# Altium schematic sheet LED_garland.SchDoc
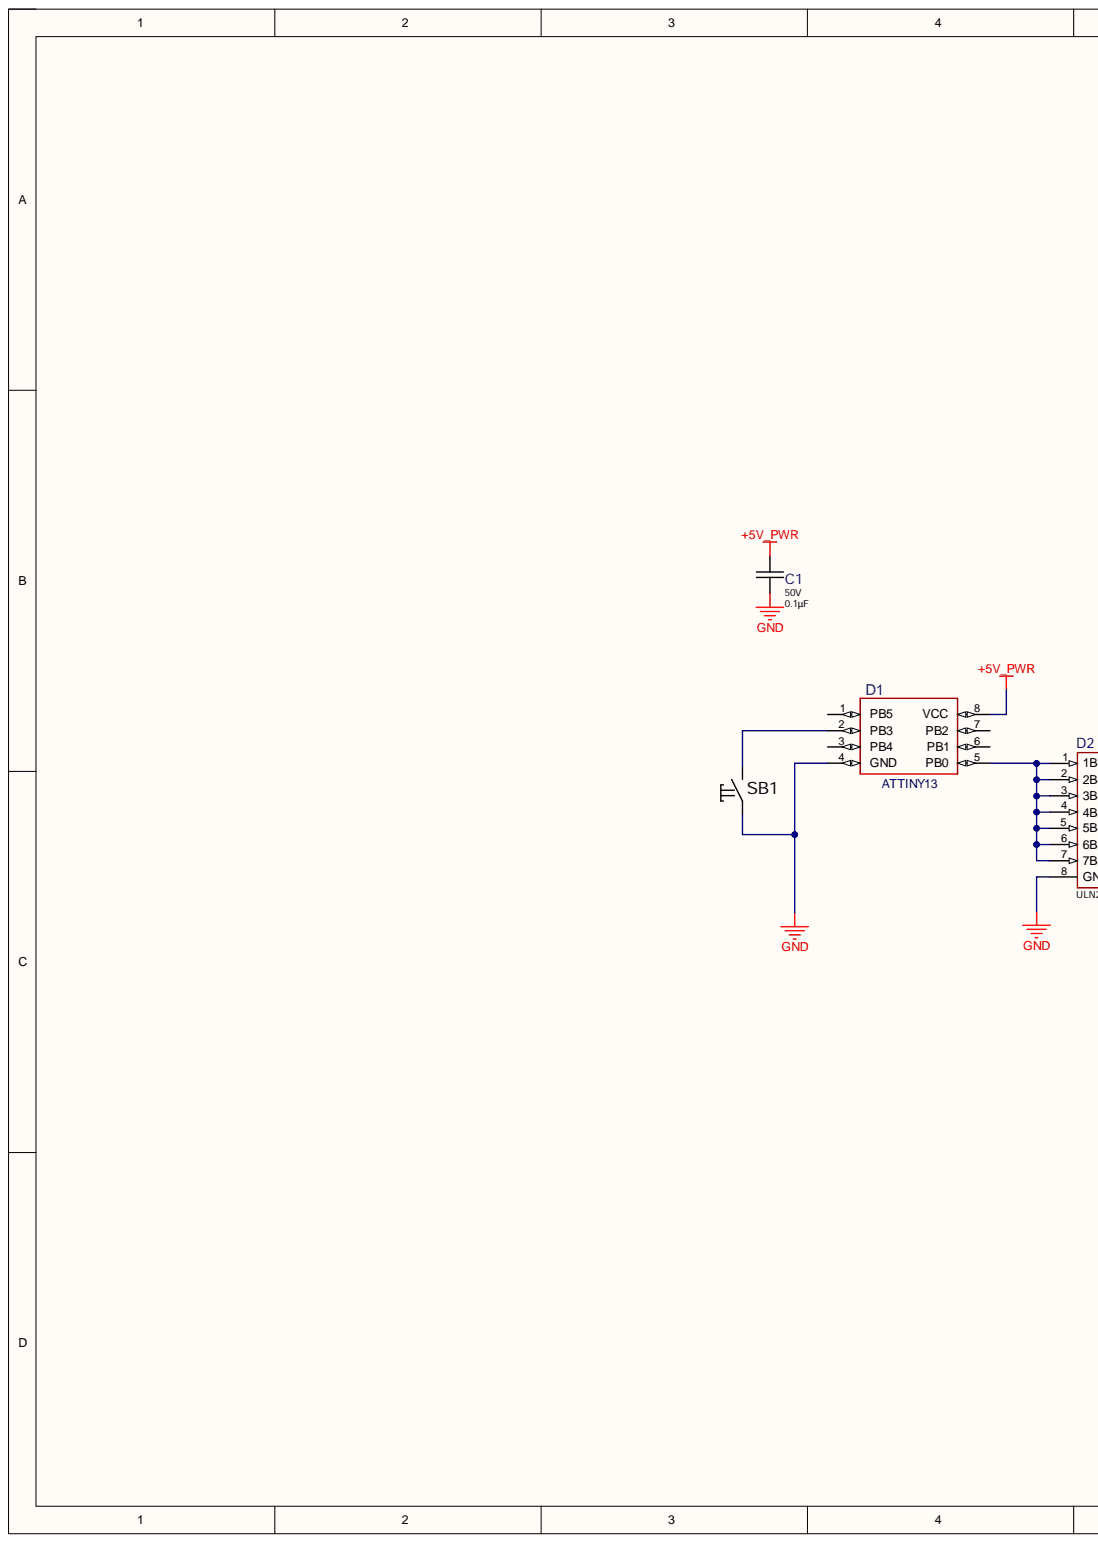
[diagram p0: LED_garland.SchDoc, left]
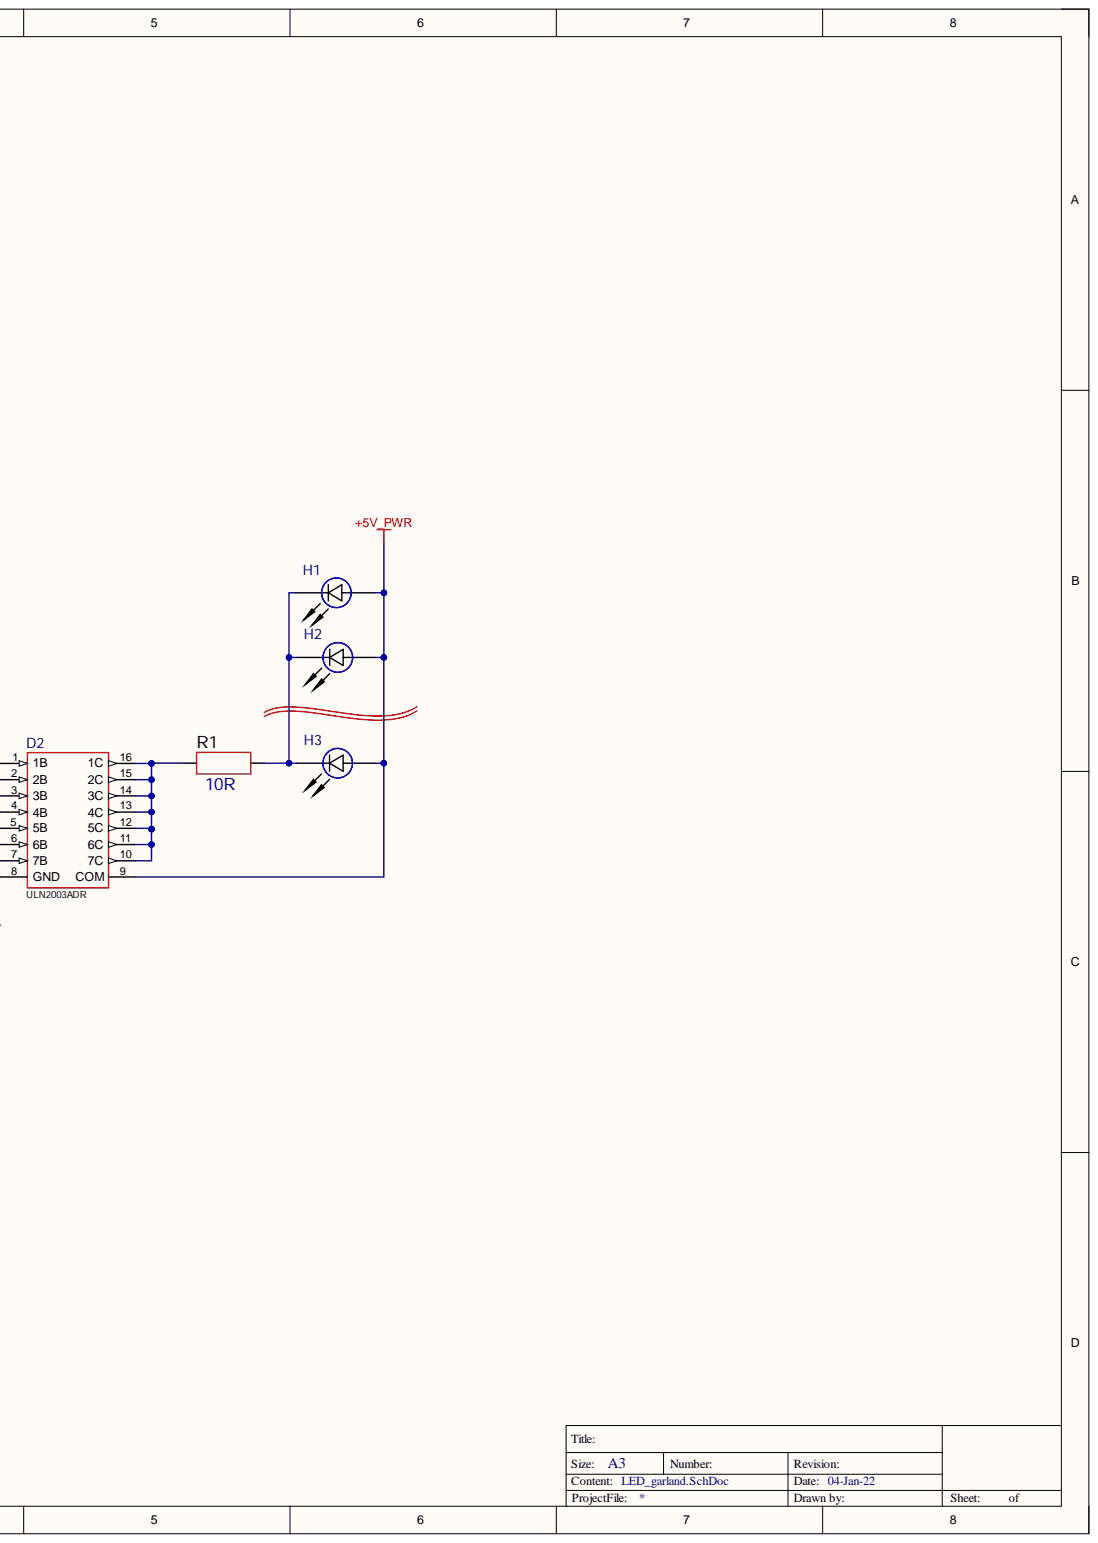
[diagram p1: LED_garland.SchDoc, right]

## Parts
C1  value 0.1μF  Voltage: 50V  [CAP: 1206]  (hidden comment: Ceramic capacitor, 0.1μF, X7R, ±10%, 50V, 1206, -55...+125°C)
D1  PartNumber: ATTINY13  [8-SOIC]  (hidden comment: #NAME?)
D2  PartNumber: ULN2003ADR  [16-SO]  (hidden comment: NPN Darlington, 50V, 500mA, 4MHz, , 0.65V, , 16-SOIC, -20°C ~ 70°C)
H1  LED, Green, *, *, *, -55...+150°C  [LED: 0402B]  (value not drawn)
H2  LED, Green, *, *, *, -55...+150°C  [LED: 0402B]  (value not drawn)
H3  LED, Green, *, *, *, -55...+150°C  [LED: 0402B]  (value not drawn)
R1  value 10R  [RES: CSS2H (2512)]  (hidden comment: Resistor film, 10R, , 2512, 5W, -55...+170°C)
SB1  Tactile button, 6x6mm  [BUT: 6x3.5 TH]  (value not drawn)

## Nets
+5V_PWR: C1.2 D1.8(VCC) D2.9(COM) H1.1 H2.1 H3.1
GND: C1.1 D1.4(GND) D2.8(GND) SB1.1
NetD1_2: D1.2(PB3) SB1.2
NetD1_5: D1.5(PB0) D2.1(1B) D2.2(2B) D2.3(3B) D2.4(4B) D2.5(5B) D2.6(6B) D2.7(7B)
NetD2_10: D2.10(7C) D2.11(6C) D2.12(5C) D2.13(4C) D2.14(3C) D2.15(2C) D2.16(1C) R1.1
NetH1_2: H1.2 H2.2 H3.2 R1.2

Not connected: D1 1(PB5) 3(PB4) 6(PB1) 7(PB2)

## Library data of the parts
C1 = Ceramic_1206: Manufacturer: Yageo; PartNumber: CC1206KRX7R9BB104; Component Kind: Standard; HelpURL: https://www.digikey.com/product-detail/en/yageo/CC1206KRX7R9BB104/311-1179-1-ND/303089; Library Name: capacitor.IntLib; Package: 1206; Temperature: -55...+125°C; Tolerance: ±10%; TypeCapacitor: Ceramic capacitor; TypeDielectric: X7R; ValueManufacturerPartNumber: Ceramic capacitor, 0.1μF, X7R, ±10%, 50V, 1206, -55...+125°C
D1 = ATtiny13A: 8-bit RISC; Manufacturer: Atmel; CoreProcessor: AVR; CoreSize: 8bit; I/O_number: 8; MemoryRAM: 64 bytes; MemoryROM: 1k flash; PowerSupply: 1.8V - 5V; Speed: 20MHz; Component Kind: Standard; ComponentType: IC microcontroller; HelpURL: https://www.digikey.com/en/products/detail/microchip-technology/ATTINY13A-SH/1995467; Library Name: ic-mcu.IntLib; Package: 8-SOIC; Temperature: -40°C - 85°C (TJ); ValueManufacturerPartNumber: #NAME?
D2 = ULN2003A: Transistor Array; Manufacturer: Texas Instruments; Component Kind: Standard; CurrentCE: 500mA; Frequency: 4MHz; HelpURL: https://www.digikey.com/en/products/detail/texas-instruments/ULN2003ADR/404968; Library Name: transistor.IntLib; Package: 16-SOIC; Temperature: -20°C ~ 70°C; TypeTransistor: NPN Darlington; ValueManufacturerPartNumber: NPN Darlington, 50V, 500mA, 4MHz, , 0.65V, , 16-SOIC, -20°C ~ 70°C; VoltageCE: 50V; VoltageSaturation: 0.65V
H1-H3 = LED_single: ColorParameter: Green; Component Kind: Standard; Library Name: diode.SchLib; Temperature: -55...+150°C; TypeDiode: LED; ValueManufacturerPartNumber: LED, Green, *, *, *, -55...+150°C
R1 = Shunt: Manufacturer: Bourns; PartNumber: CSS2H-2512K-2L00F; Component Kind: Standard; HelpURL: https://www.digikey.com/product-detail/en/bourns-inc/CSS2H-2512K-2L00F/CSS2H-2512K-2L00FCT-ND/6023737; Library Name: resistor.IntLib; Package: 2512; Power: 5W; Temperature: -55...+170°C; TypeResistor: Resistor film; ValueManufacturerPartNumber: Resistor film, 10R, , 2512, 5W, -55...+170°C
SB1 = Button_tactile: Manufacturer: TE Connectivity; PartNumber: FSM2JSMAATR; Component Kind: Standard; HelpURL: https://www.digikey.com/product-detail/en/te-connectivity-alcoswitch-switches/FSM2JSMAATR/450-1792-1-ND/3503931; Library Name: electromechanics.SchLib; Package: 6x6mm; TypeButton: Tactile button; ValueManufacturerPartNumber: Tactile button, 6x6mm
